# Altium schematic sheet CC1310.SchDoc(CC1310)
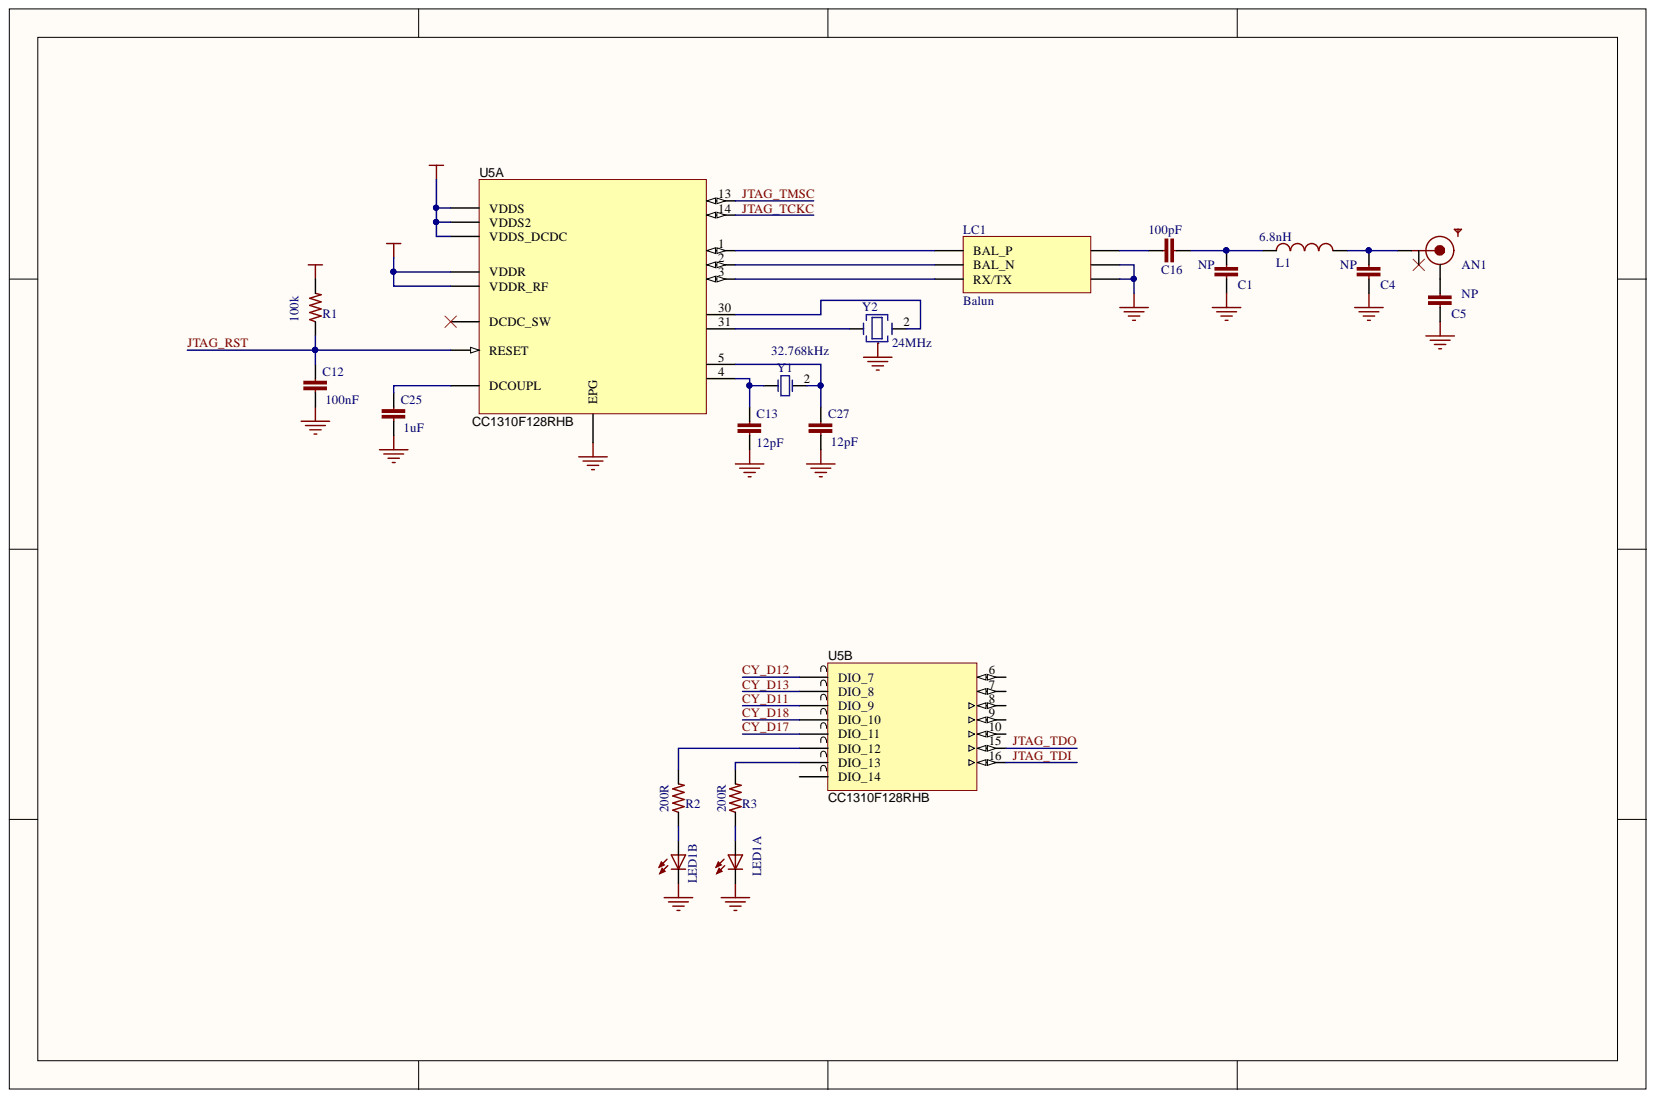
[diagram p0: CC1310.SchDoc]

## Parts
AN1  Antenna Chip  [Antena_ethertronics_M620720]  (value not drawn)
C1  NP  [C0402 - rounded]
C4  NP  [C0402 - rounded]
C5  NP  [C0603 - rounded]
C12  100nF  [C0402 - rounded]
C13  12pF  [C0603 - rounded]
C16  100pF  [C0603 - rounded]
C25  1uF  [C0603 - rounded]
C27  12pF  [C0603 - rounded]
L1  6.8nH  [L0603]
LC1  Balun  [Balun_0603]
LED1  APTB1615SURKCGKC  [APTB1615SURKCGKC]  (value not drawn)  (parts A,B)
R1  100k  [R0603 - rounded]
R2  200R  [R0603 - rounded]
R3  200R  [R0603 - rounded]
U5  CC1310F128RHB  [VQFN32-5x5]  (parts A,B)
Y1  value 32.768kHz  [XTAL FC-135]  (hidden comment: XTAL_FC135)
Y2  value 24MHz  [XTAL TSX-3225]  (hidden comment: XTAL_TSX3225)

## Nets
GND: C1.1 C4.1 C5.1 C12.2 C13.2 C25.2 C27.2 LC1.5 LC1.6 LED1.C1 LED1.C2 U5.33(EPG) Y2.3
VDDR: U5.29(VDDR) U5.32(VDDR_RF)
VDDS: R1.2 U5.11(VDDS2) U5.18(VDDS_DCDC) U5.28(VDDS)
CY_D11: U5.22(DIO_9)
CY_D12: U5.20(DIO_7)
CY_D13: U5.21(DIO_8)
CY_D17: U5.24(DIO_11)
CY_D18: U5.23(DIO_10)
JTAG_RST: C12.1 R1.1 U5.19(RESET)
JTAG_TCKC: U5.14
JTAG_TDI: U5.16
JTAG_TDO: U5.15
JTAG_TMSC: U5.13
NetAN1_1: AN1.1 C4.2 L1.2
NetAN1_3: AN1.3 C5.2
NetC1_2: C1.2 C16.2 L1.1
NetC13_1: C13.1 U5.4 Y1.1
NetC16_1: C16.1 LC1.1
NetC25_1: C25.1 U5.12(DCOUPL)
NetC27_1: C27.1 U5.5 Y1.2
NetLC1_2: LC1.2(RX/TX) U5.3
NetLC1_3: LC1.3(BAL_N) U5.2
NetLC1_4: LC1.4(BAL_P) U5.1
NetLED1_A1: LED1.A1 R3.1
NetLED1_A2: LED1.A2 R2.1
NetR2_2: R2.2 U5.25(DIO_12)
NetR3_2: R3.2 U5.26(DIO_13)
NetU5_30: U5.30 Y2.2
NetU5_31: U5.31 Y2.1

Not connected: AN1 2; U5 6 7 8 9 10 17(DCDC_SW) 27(DIO_14)

## Library data of the parts
AN1 = Antena_chip_Sub-1GHz_Kyocera: Antennas Ceramic Chip ANTENNA ISM Freq: 868 915MHz; Part Number: M620720; Vendor: AVX; Família: Antena; Value: Sub-1GHz; Library Name: Misc.IntLib; Component Kind: Standard
C1 C4 C5 C12 C13 C16 C25 C27 = C-EU-SMD: Generic Capacitor SMD; Library Name: rcl.IntLib; Component Kind: Standard
  C1 C4 C5: Value: NP
  C12: Value: 100nF
  C13 C27: Value: 12pF
  C16: Value: 100pF
  C25: Value: 1uF
L1 = L-US0603: Part Number: AIMC-0603-6N8J-T; Value: 6.8nH; Library Name: rcl.IntLib; Component Kind: Standard
LC1 = Balun_CC1310: Sub-GHz Impedance Matched Balun + LPF integrated Passive Component for Texas Instruments CC1310 Chipset; Part Number: 0850BM14E0016; Vendor: Johanson Technology; Value: Diversos; Library Name: TE.IntLib; Familia: Diversos; Component Kind: Standard
LED1 = APTB1615SURKCGKC: Vendor: Kingbright; Familia: LED_OPTO; Part Number: APTB1615SURKCGKC; Library Name: led.IntLib; Component Kind: Standard
R1 = R-US-SMD: Generic Resistor SMD; Value: 100k; Library Name: rcl.IntLib; Component Kind: Standard
R2 R3 = R-US_R0603: Resistor SMD 0603; Value: 200R; Library Name: rcl.IntLib; Component Kind: Standard
U5 = CC1310F32RHB: Sub-1 GHz and 2.4 GHz Multi-standard RF IC Family, RSM; Vendor: TEXAS INSTRUMENTS; Familia: CI's; Part Number: CC1310F128RHB; Library Name: texas.SCHLIB; Component Kind: Standard
Y1 = XTAL_FC135: CRYSTAL, RESONATOR, 32.768kHz, -20PPM/+20PPM, -40DEGC/+85DEGC, SMD; Part Number: FC-135 32.7680KA-AG0; Vendor: Epson; Familia: Diversos; Library Name: TE.SCHLIB; Component Kind: Standard
Y2 = XTAL_TSX3225: CRYSTAL, CRYSTAL OSCILLATOR, 24MHz, -15PPM/DEGC/+15PPM/DEGC, -40DEGC/+85DEGC, SMD; Part Number: TSX-3225 24.0000MF15X-AC3; Vendor: Epson; Familia: Diversos; Library Name: TE.SCHLIB; Component Kind: Standard
